# Altium schematic sheet CAN Data Diode With TXRX and Requester Rev 4.SchDoc
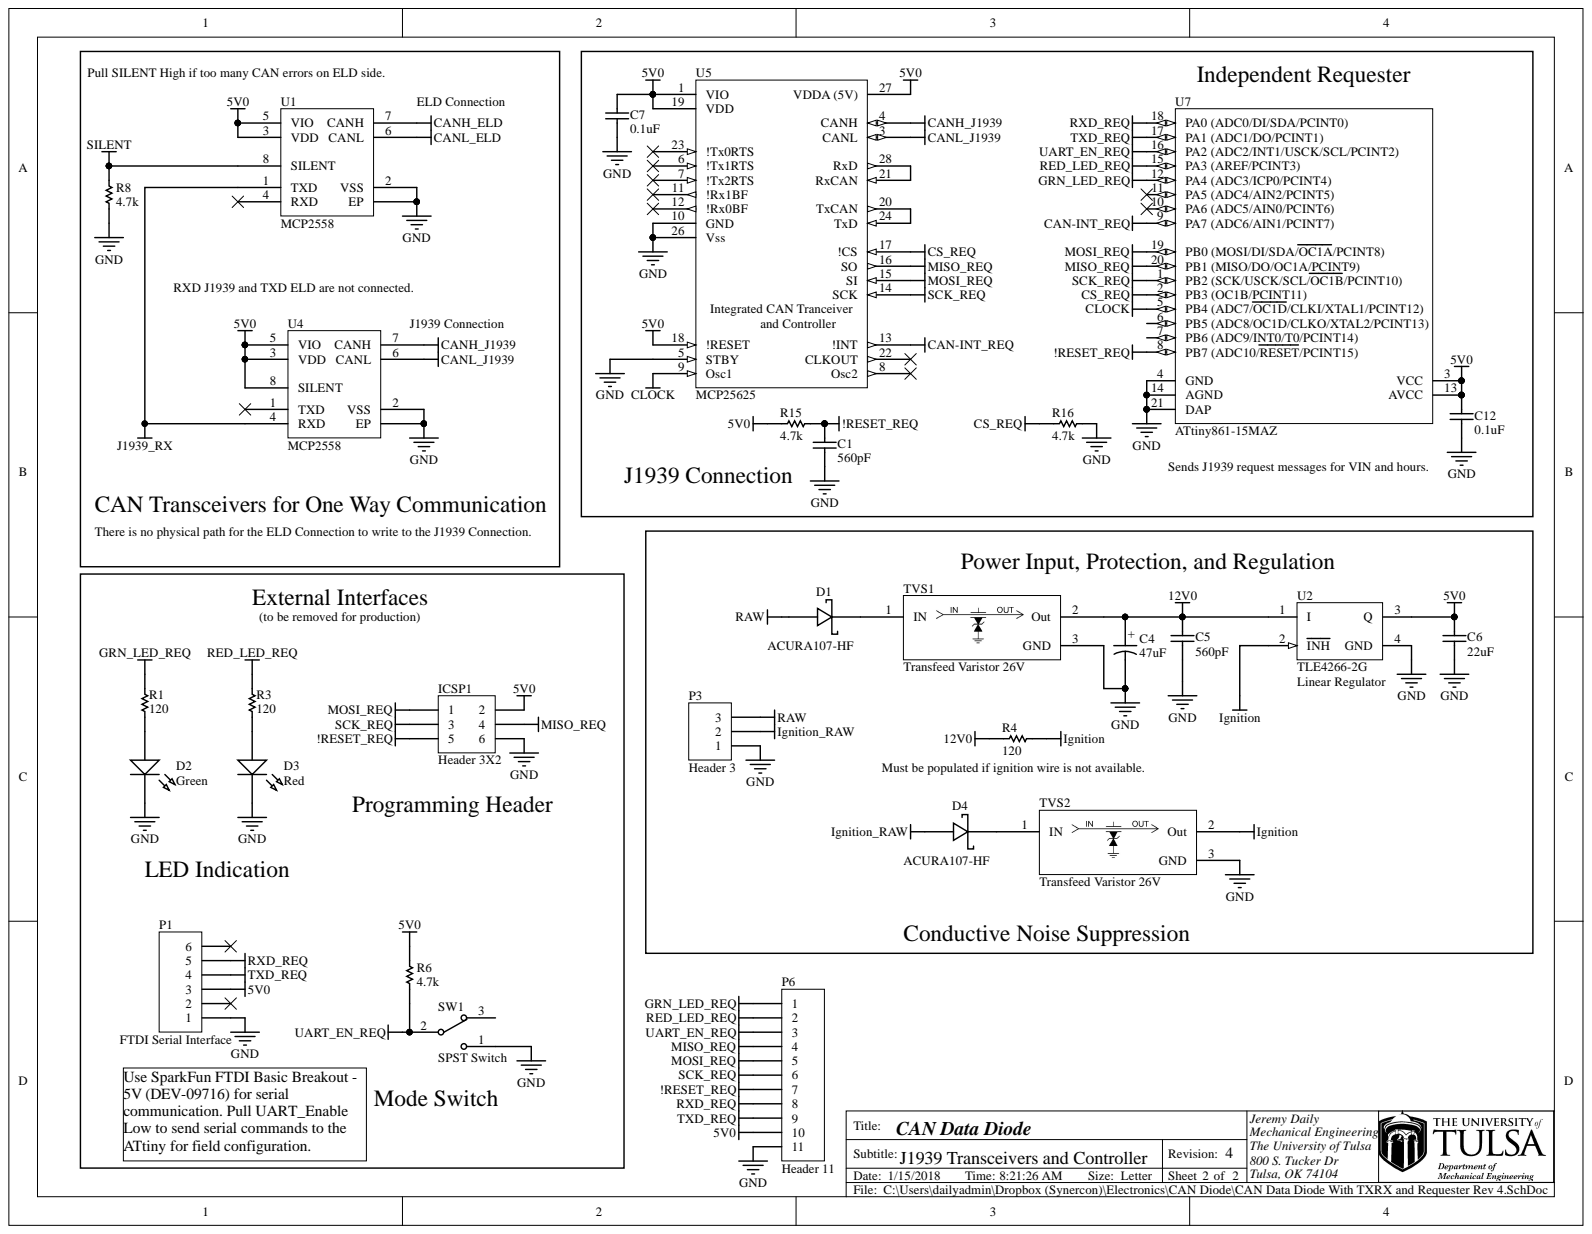
[diagram p0: CAN Data Diode With TXRX and Requester Rev 4.SchDoc]

## Parts
C1  560pF  [0603]
C4  47uF  [Elec. Cap - D]
C5  560pF  [0603]
C6  22uF  [1206]
C7  0.1uF  [0603]
C12  0.1uF  [0603]
D1  ACURA107-HF  [SMA with A and K]
D2  Green  [0805 Polarized]
D3  Red  [0805 Polarized]
D4  ACURA107-HF  [SMA with A and K]
ICSP1  Header 3X2  [HDR2X3]
P1  FTDI Serial Interface  [HDR1X6]
P3  Header 3  [HDR1X3]
P6  Header 11  [HDR1X11]
R1  120  [0603]
R3  120  [0603]
R4  120  [0603]
R6  4.7k  [0603]
R8  4.7k  [0603]
R15  4.7k  [0603]
R16  4.7k  [0603]
SW1  SPST Switch  [Slide Switch R/A]
TVS1  Transfeed Varistor 26V  [Transfeed]
TVS2  Transfeed Varistor 26V  [Transfeed]
U1  MCP2558  [TDFN]
U2  TLE4266-2G  [INF-PG-SOT223_SC-73_N]
U4  MCP2558  [TDFN]
U5  MCP25625  [SOP65P780X200-28N]
U7  ATtiny861-15MAZ  [20PC_M]

## Nets
5V0: C6.2 C7.2 C12.2 ICSP1.2 P1.3 P6.10 R6.1 R15.1 U1.3(VDD) U1.5(VIO) U2.3(Q) U4.3(VDD) U4.5(VIO) U4.8(SILENT) U5.1(VIO) U5.18(!RESET) U5.19(VDD) U5.27(VDDA_(5V)) U7.3(VCC) U7.13(AVCC)
12V0: C4.1 C5.2 R4.1 TVS1.2 U2.1(I)
!RESET_REQ: C1.2 ICSP1.5 P6.7 R15.2 U7.8(PB7_(ADC10/RESET/PCINT15))
CAN-INT_REQ: U5.13(!INT) U7.9(PA7_(ADC6/AIN1/PCINT7))
CANH_ELD: U1.7(CANH)
CANH_J1939: U4.7(CANH) U5.4(CANH)
CANL_ELD: U1.6(CANL)
CANL_J1939: U4.6(CANL) U5.3(CANL)
CLOCK: U5.9(Osc1) U7.5(PB4_(ADC7/OC1D/CLKI/XTAL1/PCINT12))
CS_REQ: R16.1 U5.17(!CS) U7.2(PB3_(OC1B/PCINT11))
GND: C1.1 C4.2 C5.1 C6.1 C7.1 C12.1 D2.2 D3.2 ICSP1.6 P1.1 P3.1 P6.11 R8.2 R16.2 SW1.1 TVS1.3 TVS2.3 U1.0(EP) U1.2(VSS) U2.4(GND) U4.0(EP) U4.2(VSS) U5.5(STBY) U5.10(GND) U5.26(Vss) U7.4(GND) U7.14(AGND) U7.21(DAP)
GRN_LED_REQ: P6.1 R1.1 U7.12(PA4_(ADC3/ICP0/PCINT4))
Ignition: R4.2 TVS2.2 U2.2(INH)
Ignition_RAW: D4.1 P3.2
J1939_RX: U1.1(TXD) U4.4(RXD)
MISO_REQ: ICSP1.4 P6.4 U5.16(SO) U7.20(PB1_(MISO/DO/OC1A/PCINT9))
MOSI_REQ: ICSP1.1 P6.5 U5.15(SI) U7.19(PB0_(MOSI/DI/SDA/OC1A/PCINT8))
RAW: D1.1 P3.3
RED_LED_REQ: P6.2 R3.1 U7.15(PA3_(AREF/PCINT3))
RXD_REQ: P1.5 P6.8 U7.18(PA0_(ADC0/DI/SDA/PCINT0))
SCK_REQ: ICSP1.3 P6.6 U5.14(SCK) U7.1(PB2_(SCK/USCK/SCL/OC1B/PCINT10))
SILENT: R8.1 U1.8(SILENT)
TXD_REQ: P1.4 P6.9 U7.17(PA1_(ADC1/DO/PCINT1))
UART_EN_REQ: P6.3 R6.2 SW1.2 U7.16(PA2_(ADC2/INT1/USCK/SCL/PCINT2))
NetD1_2: D1.2 TVS1.1
NetD2_1: D2.1 R1.2
NetD3_1: D3.1 R3.2
NetD4_2: D4.2 TVS2.1
NetU5_20: U5.20(TxCAN) U5.24(TxD)
NetU5_21: U5.21(RxCAN) U5.28(RxD)

Not connected: P1 2 6; SW1 3; U1 4(RXD); U4 1(TXD); U5 6(!Tx1RTS) 7(!Tx2RTS) 8(Osc2) 11(!Rx1BF) 12(!Rx0BF) 22(CLKOUT) 23(!Tx0RTS); U7 6(PB5_(ADC8/OC1D/CLKO/XTAL2/PCINT13)) 7(PB6_(ADC9/INT0/T0/PCINT14)) 10(PA6_(ADC5/AIN0/PCINT6)) 11(PA5_(ADC4/AIN2/PCINT5))

## Library data of the parts
C1 C5 C7 C12 = Cap: 560pF ±10% 100V Ceramic Capacitor X7R 0603 (1608 Metric); Manufacturer: AVX Corporation; PartNumber: 06031C561K4T2A; Component Kind: Standard; Library Name: SSS Integrated Library.IntLib; Signal Integrity: Cap; Simulation: CAP; Supplier 1: Digi-Key; Supplier Part Number 1: 478-9867-1-ND
C4 = Electrolytic Cap: 47µF 35V Aluminum Capacitors Radial, Can - SMD 5000 Hrs @ 105°C; Manufacturer: Panasonic Electronic Components; PartNumber: EEE-HDV470XAP; Component Kind: Standard; Library Name: SSS Integrated Library.IntLib; Supplier 1: Digi-Key; Supplier Part Number 1: PCE4968CT-ND
C6 = Cap: 22µF ±20% 25V Ceramic Capacitor X5R 1206 (3216 Metric); Manufacturer: Murata Electronics North America; PartNumber: GRT31CR61E226ME01L; Component Kind: Standard; Library Name: SSS Integrated Library.IntLib; Signal Integrity: Cap; Simulation: CAP; Supplier 1: Digi-Key; Supplier Part Number 1: 490-12451-1-ND
D1 D4 = Schottky Diode: Diode Schottky 1000V 1A Surface Mount DO-214AC (SMA); Manufacturer: Comchip Technology; Component Kind: Standard; Library Name: SSS Integrated Library.IntLib; PartNumber: ACURA107-HF; Supplier 1: Digi-Key; Supplier Part Number 1: 641-1884-1-ND
D2 = LED - Green: Green 570nm LED Indication - Discrete 2.2V 0805 (2012 Metric); Manufacturer: OSRAM Opto Semiconductors Inc.; PartNumber: LG R971-KN-1; Component Kind: Standard; Library Name: SSS Integrated Library.IntLib; Supplier 1: Digi-Key; Supplier Part Number 1: 475-1410-1-ND
D3 = LED - Red: Red 633nm LED Indication - Discrete 2V 0805 (2012 Metric); Manufacturer: OSRAM Opto Semiconductors Inc.; PartNumber: LS R976-NR-1; Component Kind: Standard; Library Name: SSS Integrated Library.IntLib; Supplier 1: Digi-Key; Supplier Part Number 1: 475-1278-1-ND
ICSP1 = Header 3X2: CONN HEADER 6POS DUAL .100 TIN; Manufacturer: 3M; PartNumber: 929836-01-03-RK; Component Kind: Standard; Library Name: Miscellaneous Connectors.IntLib; Signal Integrity: Connector; Supplier 1: Digi-Key; Supplier Part Number 1: 929836E-01-03-ND
P1 = Header 6: CONN HEADER .100 SNGL STR 6POS; Manufacturer: 3M; PartNumber: 929400-01-06-RK; Component Kind: Standard; Library Name: Miscellaneous Connectors.IntLib; Signal Integrity: Connector; Supplier 1: Digi-Key; Supplier Part Number 1: 929400E-01-06-ND
P3 = Header 3: Header, 3-Pin; Component Kind: Standard; Library Name: Miscellaneous Connectors.IntLib; Signal Integrity: Connector
P6 = Header 11: Header, 11-Pin; Component Kind: Standard; LatestRevisionDate: 17-Jul-2002; LatestRevisionNote: Re-released for DXP Platform.; Library Name: Miscellaneous Connectors.IntLib; Signal Integrity: Connector; Publisher: Altium Limited
R1 R3 R4 = Chip Resistor: 120 Ohm ±1% 0.1W, 1/10W Chip Resistor 0603 (1608 Metric) Automotive AEC-Q200 Thick Film; Manufacturer: Stackpole Electronics Inc.; PartNumber: RMCF0603FT120R; Component Kind: Standard; Library Name: SSS Integrated Library.IntLib; Supplier 1: Digi-Key; Supplier Part Number 1: RMCF0603FT120RCT-ND
R6 R8 R15 R16 = Chip Resistor: 4.7 kOhms ±1% 0.1W, 1/10W Chip Resistor 0603 (1608 Metric) Automotive AEC-Q200, High Voltage Thick Film; Manufacturer: Rohm Semiconductor; PartNumber: KTR03EZPF4701; Component Kind: Standard; Library Name: SSS Integrated Library.IntLib; Supplier 1: Digi-Key; Supplier Part Number 1: RHM4.7KAYCT-ND
SW1 = SPDT Slide Switch: SWITCH SLIDE SPDT 200MA 30V; Manufacturer: TE Connectivity AMP Connectors; PartNumber: 1825232-1; Component Kind: Standard; Library Name: SSS Integrated Library.IntLib; Supplier 1: Digi-Key; Supplier Part Number 1: A107673-ND
TVS1 TVS2 = Transfeed: 34.5V 80A Varistor 1 Circuit Surface Mount 0805 (2012 Metric); Manufacturer: AVX; PartNumber: V2AF126C600Y2EDP; Component Kind: Standard; Library Name: TU Custom Parts Schematics.SchLib; Supplier 1: Digi-Key; Supplier Part Number 1: 478-10038-1-ND
U1 U4 = MCP2558FD: Microchip CAN FD Transceiver with Silent Mode; PartNumber: MCP2558FDT-H/MNY; Component Kind: Standard; Library Name: TU Custom Parts Schematics.SchLib; Manufacturer: Microchip; Supplier 1: Arrow
  U1: Supplier Part Number 1: MCP2558FDT-H/MNY
  U4: Supplier Part Number 1: MCP2558FDT-H/MNYCT-ND
U2 = TLE4266-2G: 5 V Low Drop Voltage Regulator, 5.5 to 45 V Supply, -40 to 150 degC, PG-SOT223 (SC-73), Reel, Green; Manufacturer: Infineon; Component Kind: Standard; Library Name: Infineon_Power_Supply_Voltage_Regulator.IntLib; PartNumber: TLE4266-2G; Supplier 1: Digi-Key; Supplier Part Number 1: TLE42662GHTMA2CT-ND
U5 = MCP25625: CAN Interface IC CAN Controller with Int. Transceiver  28-QFNP; Manufacturer: Microchip; PartNumber: MCP25625-E/SS; Component Kind: Standard; Library Name: SSS Schematic Library.SchLib; Supplier 1: Digi-Key; Supplier Part Number 1: MCP25625-E/SS-ND
U7 = ATtiny861-15MAZ: AVR Automotive, AEC-Q100, AVR® ATtiny Microcontroller IC 8-Bit 16MHz 8KB (4K x 16) FLASH 20-QFN (4x4); Manufacturer: Microchip Technology; Component Kind: Standard; Library Name: Atmel Microcontroller AVR Automotive.IntLib; PartNumber: ATTINY861-15MAZ; Supplier 1: Digi-Key; Supplier Part Number 1: 1611-ATTINY861-15MAZCT-ND
